# Altium schematic sheet PWR2.SchDoc(PWR2)
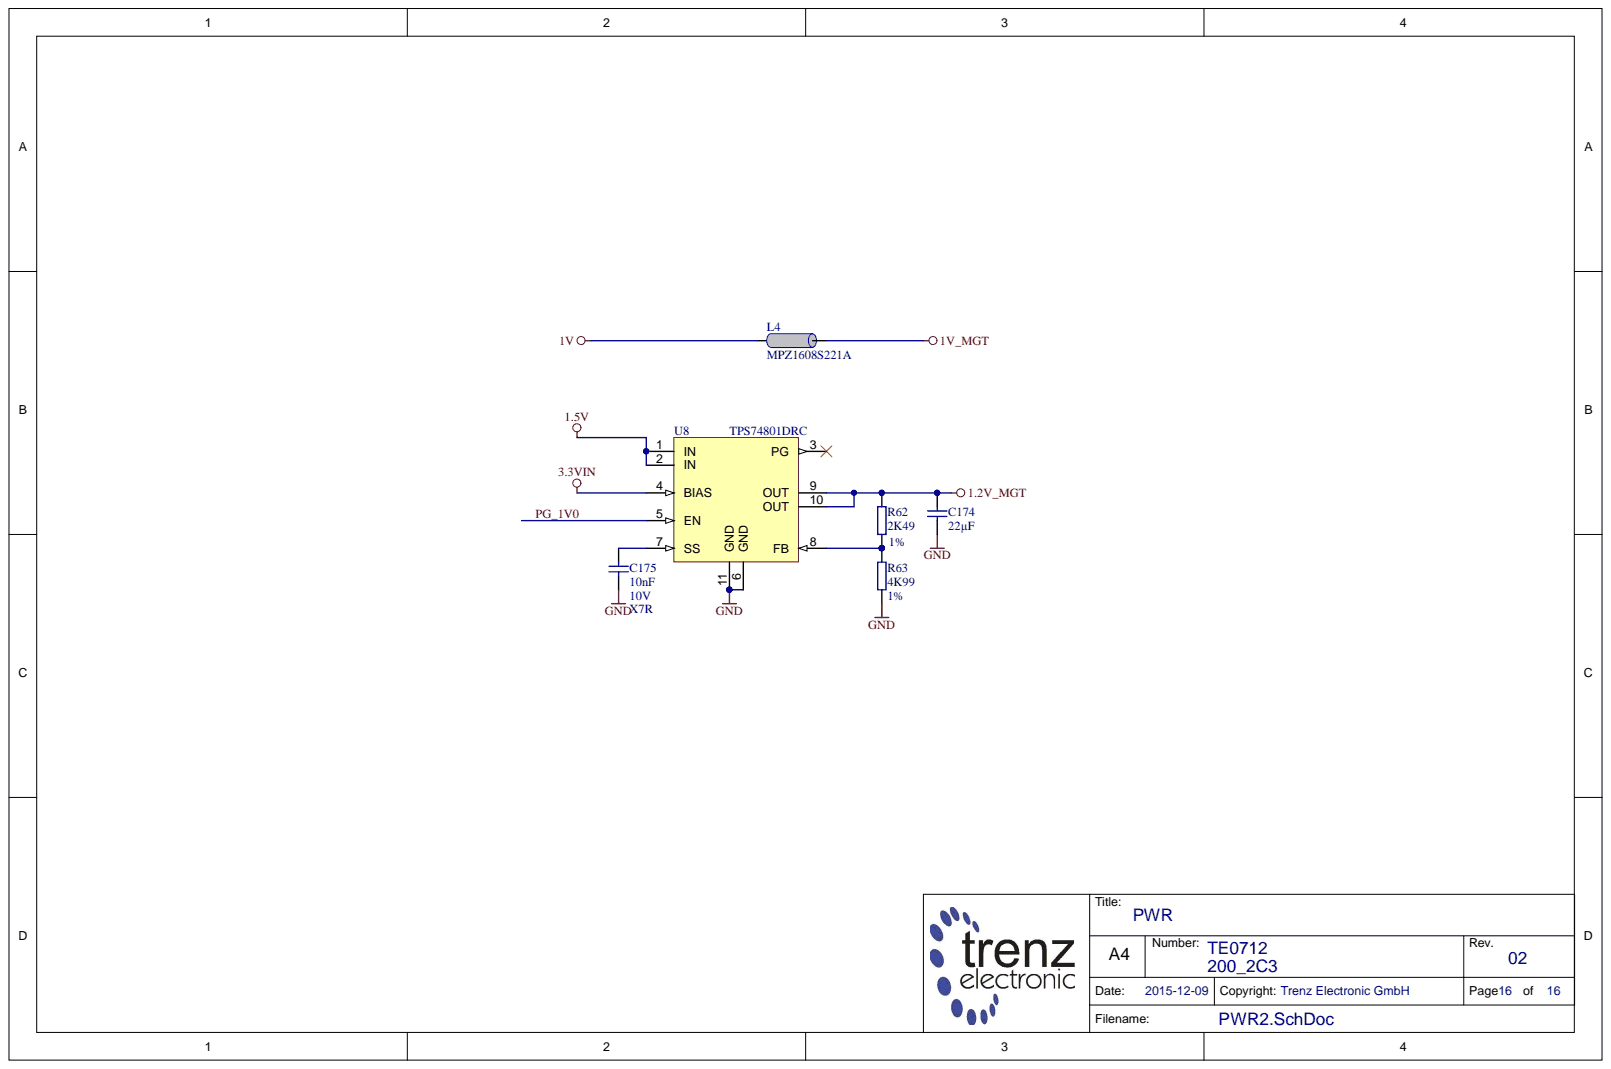
[diagram p0: PWR2.SchDoc]

## Parts
C174  22µF  [CAPC2012X135N]
C175  10nF  Technology: X7R  Voltage: 10V  [CAPC0603X33N]
L4  MPZ1608S221A  [INDC1608X95N]
R62  2K49  Tolerance: 1%  [RESC1005X40N]
R63  4K99  Tolerance: 1%  [RESC1005X40N]
U8  TPS74801DRC  [SON50P300X300X100-11N-TI]

## Nets
1.2V_MGT: C174.1 R62.1 U8.9(OUT) U8.10(OUT)
1.5V: U8.1(IN) U8.2(IN)
1V: L4.1
1V_MGT: L4.2
3.3VIN: U8.4(BIAS)
GND: C174.2 C175.2 R63.2 U8.6(GND) U8.11(GND)
PG_1V0: U8.5(EN)
NetC175_1: C175.1 U8.7(SS)
NetR62_2: R62.2 R63.1 U8.8(FB)

Not connected: U8 3(PG)

## Library data of the parts
C174 = 23410: KON 22µF 6,3V  X5R SMD; Database Table Name: C_2012_0805; H-ART: CL21A226MQQNNNG; Mixed: SMT; Value: 22u; Voltage: 6.3V; AN: 23410; Case: 2012 (0805); Component Kind: Standard; Library Name: TE.DbLib; Technology: X5R
C175 = 26312: KON 10nF X7R 10V SMD 10%; Database Table Name: C_0603_0201; H-ART: GRM033R71A103KA01D; Mixed: SMT; Preferred: Yes; Value: 10n; AN: 26312; Case: 0603 (0201); Component Kind: Standard; Library Name: TE.DbLib; Tolerance: 10%
L4 = 22718: Ferritdurchführung MPZ1608S221A; Database Table Name: L_1608_0603; Mixed: SMT; Preferred: Yes; Value: 220 OHm @ 100MHz; AN: 22718; Case: 1608 (0603); Component Kind: Standard; Library Name: TE.DbLib; Trenz-Stock: Yes; HelpURL: B:\AD\adlib\datasheet\MPZ1608
R62 = 22753: WID SMD 2K49 1% 0,063W; Database Table Name: R_1005_0402; Mixed: SMT; Preferred: Yes; Value: 2.49K; AN: 22753; Case: 1005 (0402); Component Kind: Standard; Library Name: TE.DbLib
R63 = 22754: WID SMD 4K99 1% 0,063W; Database Table Name: R_1005_0402; H-ART: RC0402FR-074K99L; Mixed: SMT; Preferred: Yes; Value: 4.99K; AN: 22754; Case: 1005 (0402); Component Kind: Standard; Library Name: TE.DbLib
U8 = 25235: Database Table Name: LREG; Mixed: SMT; Trenz-Stock: Yes; AN: 25235; Case: DRC (S-PVSON-N10); Component Kind: Standard; Description: TPS74801DRC; Library Name: TE.DbLib; HelpURL: B:\AD\adlib\datasheet\TPS74801
